# Altium schematic sheet POWER.SchDoc(POWER)
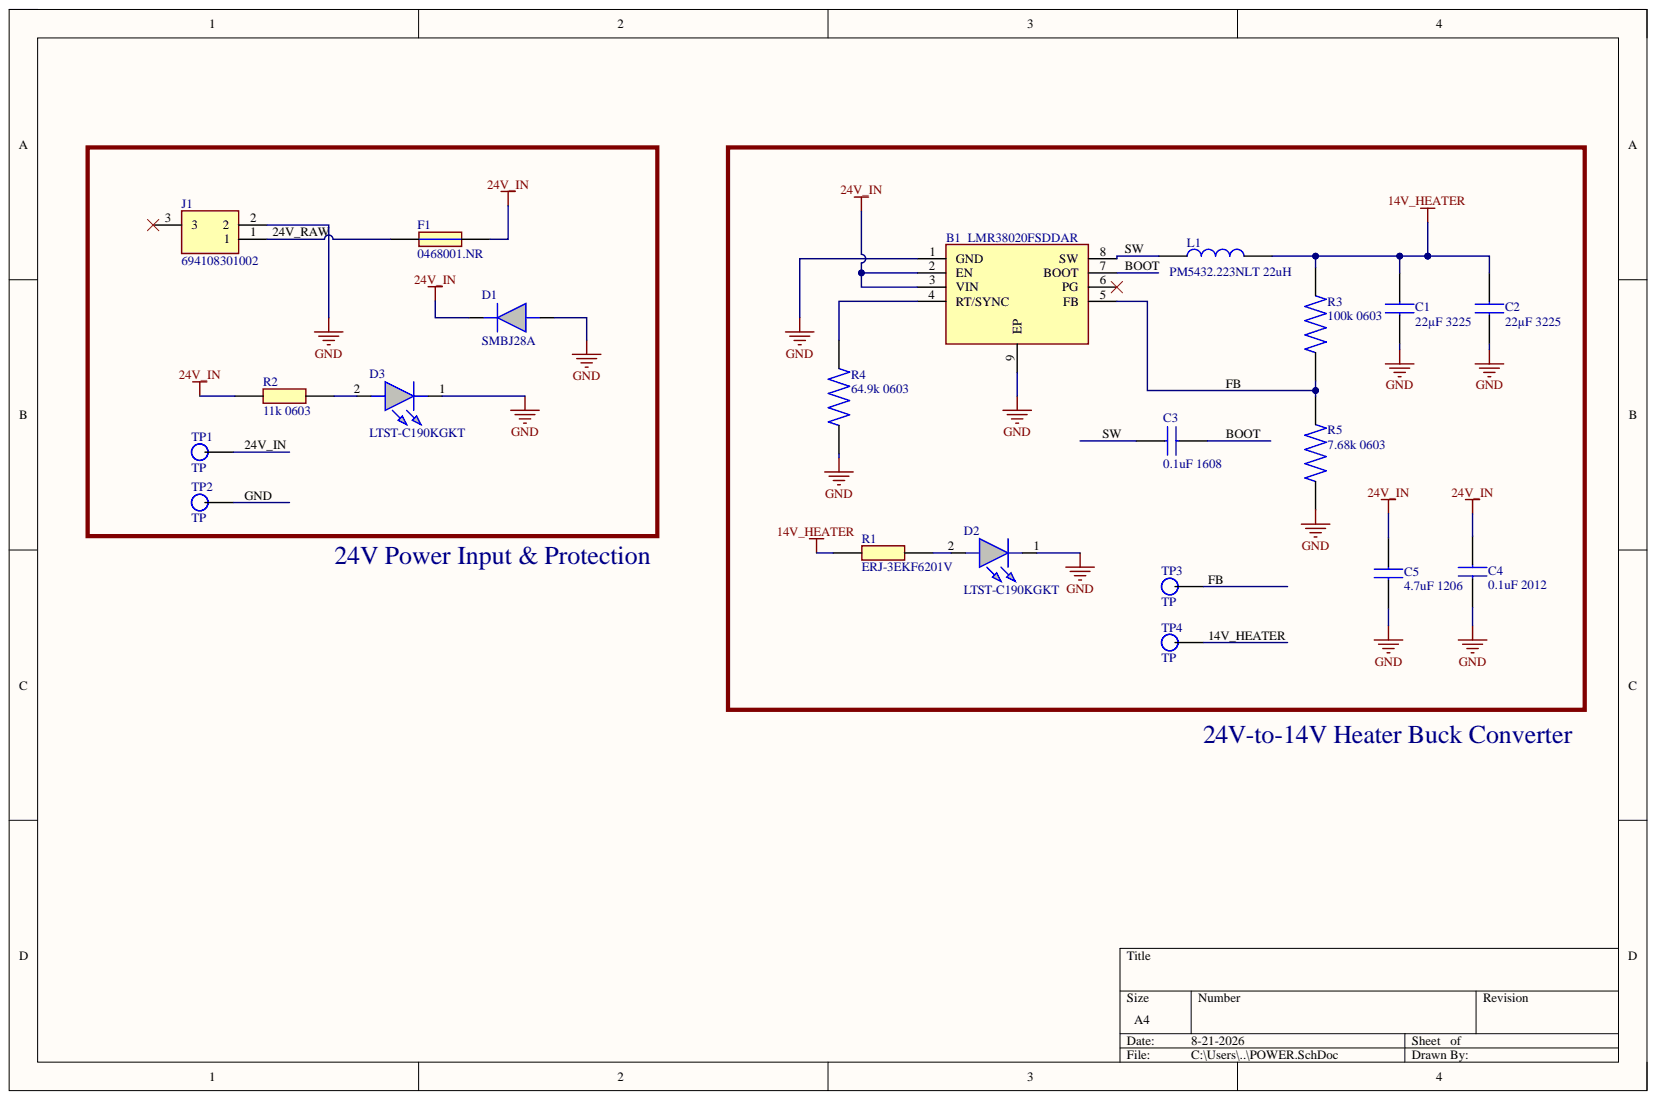
[diagram p0: POWER.SchDoc]

## Parts
B1  LMR38020FSDDAR  [SOIC127P600X170-9N]
C1  22µF 3225  [CAPC3225X230N]
C2  22µF 3225  [CAPC3225X230N]
C3  0.1uF 1608  [CAPC1608X80N]
C4  0.1uF 2012  [CAPC2012X145N]
C5  4.7uF 1206  [CAPC3216X190N]
D1  SMBJ28A  [DIOM5436X261N]
D2  LTST-C190KGKT  [LEDC1608X80N]
D3  LTST-C190KGKT  [LEDC1608X80N]
F1  0468001.NR  [FUSC3215X76N]
J1  694108301002  [694108301002]
L1  PM5432.223NLT 22uH  [PM5432223NLT]
R1  ERJ-3EKF6201V  [RESC1608X55N]
R2  11k 0603  [RESC1608X55N]
R3  100k 0603  [RES_ERJ3EKF1003V]
R4  64.9k 0603  [RES_ERJ3EKF6492V]
R5  7.68k 0603  [RES_ERJ3EKF7681V]
TP1  TP  [TESTPOINT]
TP2  TP  [TESTPOINT]
TP3  TP  [TESTPOINT]
TP4  TP  [TESTPOINT]

## Nets
14V_HEATER: C1.2 C2.2 L1.2 R1.2 R3.2 TP4.1
24V_IN: B1.2(EN) B1.3(VIN) C4.2 C5.2 D1.1 F1.2 R2.2 TP1.1
24V_RAW: F1.1 J1.1
BOOT: B1.7(BOOT) C3.1
FB: B1.5(FB) R3.1 R5.2 TP3.1
GND: B1.1(GND) B1.9(EP) C1.1 C2.1 C4.1 C5.1 D1.2 D2.1 D3.1 J1.2 R4.1 R5.1 TP2.1
SW: B1.8(SW) C3.2 L1.1
NetB1_4: B1.4(RT/SYNC) R4.2
NetD2_2: D2.2 R1.1
NetD3_2: D3.2 R2.1

Not connected: B1 6(PG); J1 3

## Library data of the parts
B1 = LMR38020FSDDAR: Switching Voltage Regulators SIMPLE SWITCHER power converter 4.2-V to 80-V, 2-A, synchronous buck with 40- uA IQ 8-SO PowerPAD -40 to 150; Manufacturer_Name: Texas Instruments; Geometry.Height: 1.7mm; Component Kind: Standard; Datasheet Link: https://www.ti.com/lit/ds/symlink/lmr38020.pdf?ts=1680684106501&ref_url=https%253A%252F%252Fwww.ti.com%252Fproduct%252FLMR38020%252Fpart-details%252FLMR38020FSDDAR; Library Name: LMR38020FSDDAR.SchLib; Manufacturer_Part_Number: LMR38020FSDDAR; Mouser Part Number: 595-LMR38020FSDDAR; Mouser Price/Stock: https://www.mouser.co.uk/ProductDetail/Texas-Instruments/LMR38020FSDDAR?qs=Li%252BoUPsLEntEBCY4Tfvw5g%3D%3D
C1 C2 = C3225X7R1E226M250AB: MULTILAYER CERAMIC CHIP CAPACITORS, 3225, Commercial grade, general (Up to 75V); Manufacturer_Name: TDK; Geometry.Height: 2.3mm; Component Kind: Standard; Datasheet Link: https://product.tdk.com/info/en/catalog/datasheets/mlcc_commercial_midvoltage_en.pdf; Library Name: C3225X7R1E226M250AB.SchLib; Manufacturer_Part_Number: C3225X7R1E226M250AB; Mouser Part Number: 810-C3225X7R1EM250AB; Mouser Price/Stock: https://www.mouser.co.uk/ProductDetail/TDK/C3225X7R1E226M250AB?qs=gZXFycFWdAOxso2lxwRfpg%3D%3D
C3 = C1608X7R1H104K080AA: MULTILAYER CERAMIC CHIP CAPACITORS, 1608, Commercial grade, general (Up to 75V); Manufacturer_Name: TDK; Geometry.Height: 0.8mm; Component Kind: Standard; Datasheet Link: https://product.tdk.com/info/en/catalog/datasheets/mlcc_commercial_midvoltage_en.pdf; Library Name: C1608X7R1H104K080AA.SchLib; Manufacturer_Part_Number: C1608X7R1H104K080AA; Mouser Part Number: 810-C1608X7R1H104K; Mouser Price/Stock: https://www.mouser.co.uk/ProductDetail/TDK/C1608X7R1H104K080AA?qs=NRhsANhppD8dEJli2CDBHA%3D%3D
C4 = C2012X7R2A104K125AE: Multilayer Ceramic Capacitors MLCC - SMD/SMT 2012 0.1uF 100volts X7R 10% Soft Term; Manufacturer_Name: TDK; Geometry.Height: 1.45mm; Component Kind: Standard; Datasheet Link: https://product.tdk.com/info/en/catalog/datasheets/mlcc_commercial_midvoltage_en.pdf; Library Name: C2012X7R2A104K125AE.SchLib; Manufacturer_Part_Number: C2012X7R2A104K125AE; Mouser Part Number: 810-C2012X7R2A104KAE; Mouser Price/Stock: https://www.mouser.co.uk/ProductDetail/TDK/C2012X7R2A104K125AE?qs=n%2FVzw7c4a0kn2AI72VWcwA%3D%3D
C5 = CGA5L1X7R2A475K160AC: Multilayer Ceramic Capacitors MLCC - SMD/SMT 1206 100VDC 4.7uF 10% 1.6mm AEC-Q200; Manufacturer_Name: TDK; Geometry.Height: 1.9mm; Component Kind: Standard; Library Name: CGA5L1X7R2A475K160AC.SchLib; Manufacturer_Part_Number: CGA5L1X7R2A475K160AC; Mouser Part Number: 810-CGA5L7R2A475K160; Mouser Price/Stock: https://www.mouser.co.uk/ProductDetail/TDK/CGA5L1X7R2A475K160AC?qs=17ckDYBRdelJ4ksCO5KBeA%3D%3D
D1 = SMBJ28A: Littelfuse SMBJ28A Uni-Directional TVS Diode, 600W peak, 2-Pin DO-214AA; Geometry.Height: 2.61mm; Component Kind: Standard; Datasheet Link: https://componentsearchengine.com/Datasheets/2/SMBJ28A.pdf; Library Name: SMBJ28A.SchLib; Manufacturer_Name: LITTELFUSE; Manufacturer_Part_Number: SMBJ28A; Mouser Part Number: 576-SMBJ28A; Mouser Price/Stock: https://www.mouser.co.uk/ProductDetail/Littelfuse/SMBJ28A?qs=JJML70Qc14vmSTVTa1LvqQ%3D%3D
D2 D3 = LTST-C190KGKT: Lite-On LTST-C190KGKT, 571 nm Green LED, 1608 (0603) SMD package; Geometry.Height: 0.8mm; Component Kind: Standard; Library Name: LTST-C190KGKT.SchLib; Manufacturer_Name: Lite-On; Manufacturer_Part_Number: LTST-C190KGKT; Mouser Part Number: 859-LTST-C190KGKT; Mouser Price/Stock: https://www.mouser.co.uk/ProductDetail/Lite-On/LTST-C190KGKT?qs=205qCy6M2Q%252BAdcgwUZktqw%3D%3D
F1 = 0468001.NR: Surface Mount Fuses 1A Slo-Blo; Manufacturer_Name: LITTELFUSE; Geometry.Height: 0.762mm; Component Kind: Standard; Datasheet Link: https://www.littelfuse.com/~/media/electronics/datasheets/fuses/littelfuse_fuse_468_datasheet.pdf.pdf; Library Name: 0468001.NR.SchLib; Manufacturer_Part_Number: 0468001.NR; Mouser Part Number: 576-0468001.NR; Mouser Price/Stock: https://www.mouser.co.uk/ProductDetail/Littelfuse/0468001.NR?qs=ar9f0rk5DXBYH1VlQUX7jA%3D%3D
J1 = 694108301002: WR-DCPJ_THT_R/A_Plastic DCPowerJack_THT_R/A_Plastic_CenterPin_ 2.5; Manufacturer_Name: Wurth Elektronik; Geometry.Height: 11mm; Component Kind: Standard; Datasheet Link: https://www.we-online.com/catalog/datasheet/694108301002.pdf; Library Name: 694108301002.SchLib; Manufacturer_Part_Number: 694108301002; Mouser Part Number: 710-694108301002; Mouser Price/Stock: https://www.mouser.co.uk/ProductDetail/Wurth-Elektronik/694108301002?qs=a9WhcLg8qCwpBR6w1flhOw%3D%3D
L1 = PM5432.223NLT: Power Inductors - SMD 22uH 5A AEC-Q200 DCR=60.63mOhms 20%; Manufacturer_Name: Yageo Group; Geometry.Height: 6mm; Arrow Part Number: PM5432.223NLT; Arrow Price/Stock: https://www.arrow.com/en/products/pm5432.223nlt/pulse-electronics-corporation?region=nac; Component Kind: Standard; Datasheet Link: https://www.digikey.com/en/products/detail/pulse-electronics/PM5432-223NLT/13693997?s=N4IgTCBcDaIAoFkCsAWAzGAdGMaByAMgCogC6AvkA; Library Name: PM5432.223NLT.SchLib; Manufacturer_Part_Number: PM5432.223NLT; Mouser Part Number: 673-PM5432.223NLT; Mouser Price/Stock: https://www.mouser.co.uk/ProductDetail/Pulse-Electronics/PM5432.223NLT?qs=pUKx8fyJudA8Ps5Zqdw%2FMg%3D%3D
R1 = ERJ-3EKF6201V: Precision Thick Film Chip Resistor, 0603, 0.1W; Manufacturer_Name: Panasonic; Geometry.Height: 0.55mm; Component Kind: Standard; Datasheet Link: http://industrial.panasonic.com/cdbs/www-data/pdf/RDA0000/AOA0000C86.pdf; Library Name: ERJ-3EKF6201V.SchLib; Manufacturer_Part_Number: ERJ-3EKF6201V; Mouser Part Number: 667-ERJ-3EKF6201V; Mouser Price/Stock: https://www.mouser.co.uk/ProductDetail/Panasonic/ERJ-3EKF6201V?qs=iBwFsHVUAOd2VkgAcHpNRw%3D%3D
R2 = ERJ-3EKF1102V: Precision Thick Film Chip Resistor, 0603, 0.1W; Manufacturer_Name: Panasonic; Geometry.Height: 0.55mm; Component Kind: Standard; Datasheet Link: http://industrial.panasonic.com/cdbs/www-data/pdf/RDA0000/AOA0000C86.pdf; Library Name: ERJ-3EKF1102V.SchLib; Manufacturer_Part_Number: ERJ-3EKF1102V; Mouser Part Number: 667-ERJ-3EKF1102V; Mouser Price/Stock: https://www.mouser.co.uk/ProductDetail/Panasonic/ERJ-3EKF1102V?qs=iBwFsHVUAOdsgJapSwaPfA%3D%3D
R3 = ERJ3EKF1003V: Res Thick Film 0603 100K Ohm 1% 0.1W(1/10W) 100ppm/C Pad SMD Automotive T/R; MF: Panasonic; MP: ERJ-3EKF1003V; Check_prices: https://www.snapeda.com/parts/ERJ-3EKF1003V/Panasonic+Electronic+Components/view-part/?ref=eda; Component Kind: Standard; Library Name: ERJ3EKF1003V.SchLib; Package: 1608-2 Panasonic; SnapEDA_Link: https://www.snapeda.com/parts/ERJ-3EKF1003V/Panasonic+Electronic+Components/view-part/?ref=snap
R4 = ERJ3EKF6492V: MF: {'manufacturer': u'Panasonic Electronic Components'}; Availability: Unavailable; Component Kind: Standard; Library Name: ERJ3EKF6492V.SchLib; MP: ERJ3EKF6492V; Package: None; Price: None
R5 = ERJ3EKF7681V: Res Thick Film 1.6 x 0.8 mm 7.68K Ohm 1% 0.1W(1/10W) 100ppm/ C Molded SMD Punched Carrier T/R; MF: Panasonic; Check_prices: https://www.snapeda.com/parts/ERJ3EKF7681V/Panasonic/view-part/?ref=eda; Component Kind: Standard; Library Name: ERJ3EKF7681V.SchLib; MP: ERJ3EKF7681V; Package: 1608-2 Panasonic; SnapEDA_Link: https://www.snapeda.com/parts/ERJ3EKF7681V/Panasonic/view-part/?ref=snap
TP1-TP4 = TP: Component Kind: Standard; Library Name: TESTPOINT.SchLib
